# Altium schematic sheet Water_Level_Indicator_PCB.SchDoc
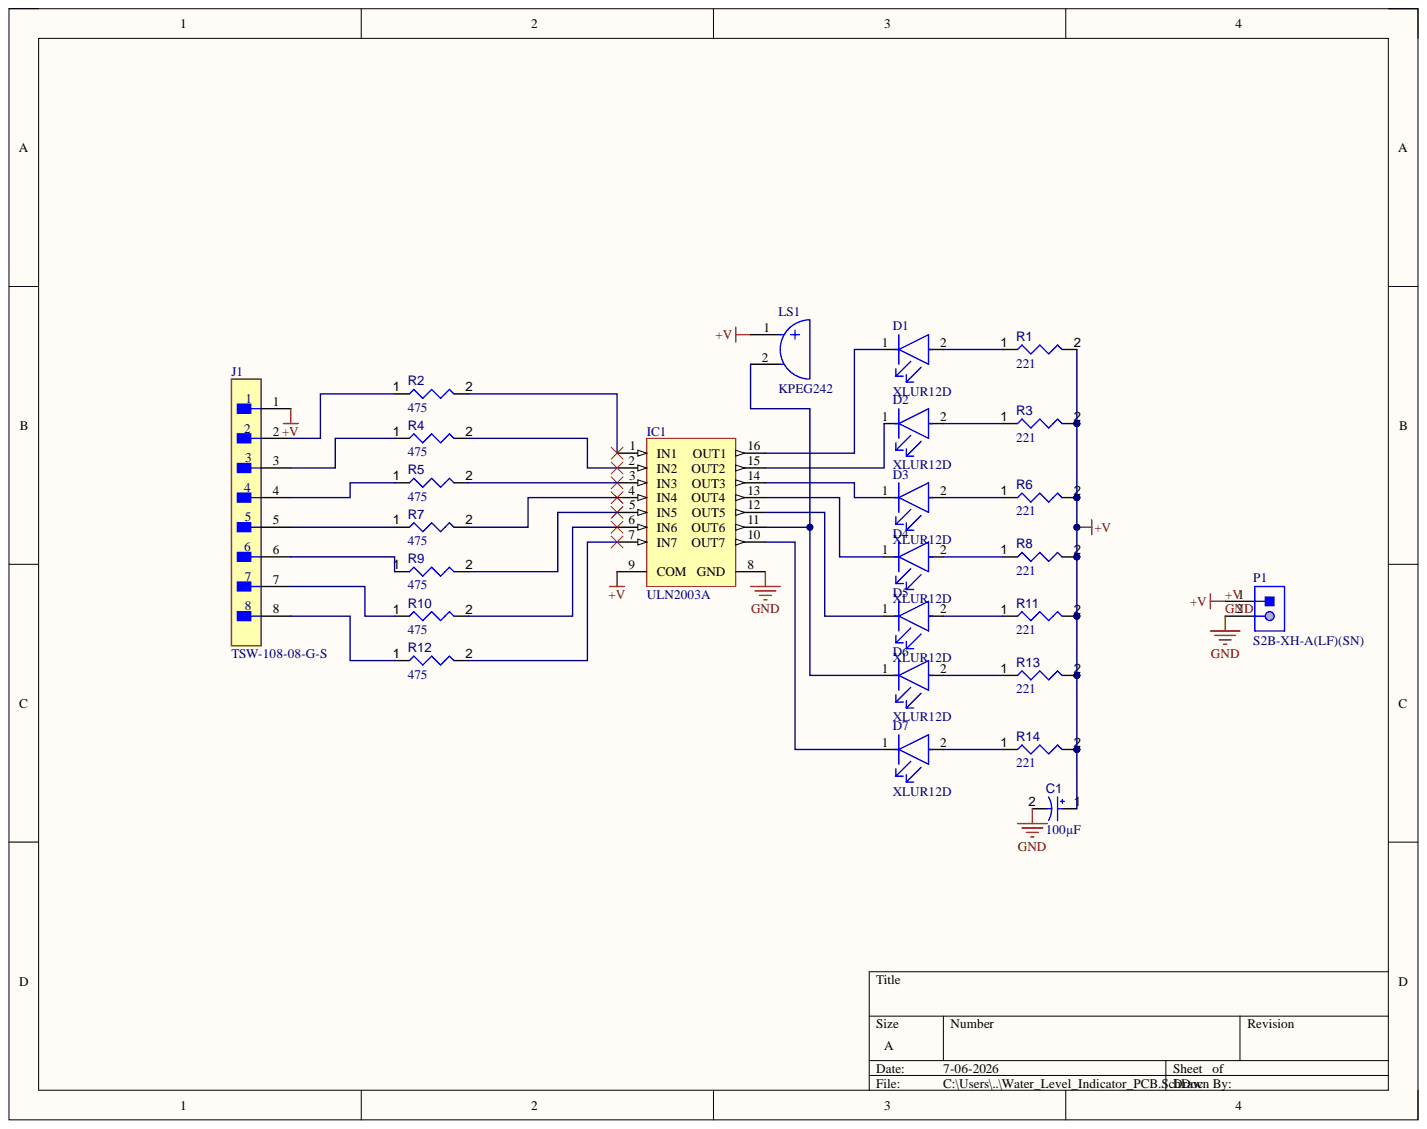
[diagram p0: Water_Level_Indicator_PCB.SchDoc]

## Parts
C1  value 100µF  [CAPPR65-350-1150X800X1250]  (hidden comment: ECA1HM101)
D1  XLUR12D  [FP-XLUR12D-MFG]
D2  XLUR12D  [FP-XLUR12D-MFG]
D3  XLUR12D  [FP-XLUR12D-MFG]
D4  XLUR12D  [FP-XLUR12D-MFG]
D5  XLUR12D  [FP-XLUR12D-MFG]
D6  XLUR12D  [FP-XLUR12D-MFG]
D7  XLUR12D  [FP-XLUR12D-MFG]
IC1  ULN2003A  [STM-DIP-16L]
J1  TSW-108-08-G-S  [FP-TSW-108-08-G-S-MFG]
LS1  KPEG242  [KINGS-KPEG242-2]
P1  S2B-XH-A(LF)(SN)  [JST-S2B-XH-A(LF)(SN)_V]
R1  value 221  [RESA60-630X240]  (hidden comment: MFR-25FBF52-221R)
R2  value 475  [RESA60-630X240]  (hidden comment: MFR-25FBF52-475R)
R3  value 221  [RESA60-630X240]  (hidden comment: MFR-25FBF52-221R)
R4  value 475  [RESA60-630X240]  (hidden comment: MFR-25FBF52-475R)
R5  value 475  [RESA60-630X240]  (hidden comment: MFR-25FBF52-475R)
R6  value 221  [RESA60-630X240]  (hidden comment: MFR-25FBF52-221R)
R7  value 475  [RESA60-630X240]  (hidden comment: MFR-25FBF52-475R)
R8  value 221  [RESA60-630X240]  (hidden comment: MFR-25FBF52-221R)
R9  value 475  [RESA60-630X240]  (hidden comment: MFR-25FBF52-475R)
R10  value 475  [RESA60-630X240]  (hidden comment: MFR-25FBF52-475R)
R11  value 221  [RESA60-630X240]  (hidden comment: MFR-25FBF52-221R)
R12  value 475  [RESA60-630X240]  (hidden comment: MFR-25FBF52-475R)
R13  value 221  [RESA60-630X240]  (hidden comment: MFR-25FBF52-221R)
R14  value 221  [RESA60-630X240]  (hidden comment: MFR-25FBF52-221R)

## Nets
+V: C1.1 IC1.9(COM) J1.1 LS1.1 P1.1 R1.2 R3.2 R6.2 R8.2 R11.2 R13.2 R14.2
GND: C1.2 IC1.8(GND) P1.2
NetD1_1: D1.1 IC1.16(OUT1)
NetD1_2: D1.2 R1.1
NetD2_1: D2.1 IC1.15(OUT2)
NetD2_2: D2.2 R3.1
NetD3_1: D3.1 IC1.14(OUT3)
NetD3_2: D3.2 R6.1
NetD4_1: D4.1 IC1.13(OUT4)
NetD4_2: D4.2 R8.1
NetD5_1: D5.1 IC1.12(OUT5)
NetD5_2: D5.2 R11.1
NetD6_1: D6.1 IC1.11(OUT6) LS1.2
NetD6_2: D6.2 R13.1
NetD7_1: D7.1 IC1.10(OUT7)
NetD7_2: D7.2 R14.1
NetIC1_1: IC1.1(IN1) R2.2
NetIC1_2: IC1.2(IN2) R4.2
NetIC1_3: IC1.3(IN3) R5.2
NetIC1_4: IC1.4(IN4) R7.2
NetIC1_5: IC1.5(IN5) R9.2
NetIC1_6: IC1.6(IN6) R10.2
NetIC1_7: IC1.7(IN7) R12.2
NetJ1_2: J1.2 R2.1
NetJ1_3: J1.3 R4.1
NetJ1_4: J1.4 R5.1
NetJ1_5: J1.5 R7.1
NetJ1_6: J1.6 R9.1
NetJ1_7: J1.7 R10.1
NetJ1_8: J1.8 R12.1

## Library data of the parts
C1 = CMP-001-00026-7: Aluminum Electrolytic Capacitor, 100 uF, +/- 20%, 50 V, -40 to 85 degC, 2-Pin THD, RoHS, Bulk; Manufacturer: Panasonic; Ripple Current: 250mA; Voltage Rating: 50V; Capacitor Type: Electrolytic; Case/Package: Radial; Component Kind: Standard; Datasheet URL: https://industrial.panasonic.com/cdbs/www-data/pdf/RDF0000/ABA0000C1218.pdf; Datasheet Version: Rev. 05, 10/2016; Diameter: 8mm; Lead Pitch: 3.5mm; Manufacturer URL: http://www.panasonic.com/; Max Operating Temperature: 85°C; Min Operating Temperature: -40°C; Mounting Technology: TH; Package Description: 2-Pin Radial Lead, Dia 8 mm, Height 12.5 mm; Package Quantity: 200; Package Reference: CAPPR65-350-1150X800X1250; Packaging: Bulk; Pins: 2; Ripple Current (AC): 250mA; RoHS Compliant: True; Tolerance: 20%; Library Name: Yuvan Bala
D1-D7 = CMP-02085-08376351-1: 627nm Red LED; 5x8.6mm, Through-Hole Mount with Red Diffused Round Lamp; Dominant Wavelength: 617nm; Forward Voltage: 1.9V; Luminous Intensity: 39mcd; Test Current: 10mA; Viewing Angle: 30°; Case/Package: Radial; Color: Red; Component Kind: Standard; Height: 8.6mm; Lens Color: Red; Lens Transparency: Diffused; Library Name: Altium Content Vault; Lifecycle Status: Production; Mount: Through Hole; RoHS: Compliant; Wavelength: 627nm
IC1 = CMP-2000-07351-1: Seven Darlington Array, 50 V, 0.5 A, -40 to 85 degC, 16-Pin DIP, RoHS, Tube; Manufacturer: STMicroelectronics; Collector Emitter Voltage V(br)ceo: 50V; DC Collector Current: 500mA; DC Current Gain hFE: 1000hFE; No. of Pins: 16Pins; Output Current: 0.5A; SVHC: NoSVHC(17-Dec-2015); Transistor Polarity: NPN; Automotive Qualification Standard: -; Component Kind: Standard; Datasheet URL: http://www2.st.com/content/ccc/resource/technical/document/datasheet/f3/6e/c8/64/4d/b3/4e/38/CD00001244.pdf/files/CD00001244.pdf/jcr:content/translations/en.CD00001244.pdf; Datasheet Version: Rev. 8, 06/2012; Library Name: Altium Content Vault; Manufacturer URL: http://www.st.com/; Mounting Technology: ThroughHole; MSL: MSL2-1year; Operating Temperature Max: 85°C; Package Description: 16-Pin DIP, Body 19.7 x 7.1 mm, Pitch 2.54 mm; Package Reference: DIP-16L; PartNumber: ULN2003A; Power Dissipation Pd: -; Product Range: -; Transistor Case Style: DIP; VOUT: 50V
J1 = CMP-02766-000001-1: CONN HEADER VERT 8POS 2.54MM; Manufacturer: Samtec; Conn Gender: Male; Conn Orientation: Straight; Number Of Contacts: 8; Automotive: No; Category: Conn; Component Kind: Standard; ComponentLink2Description: Datasheet; ComponentLink2URL: http://suddendocs.samtec.com/catalog_english/tsw_th.pdf; Contact Material: Gold,Nickel; Device Class L1: Connectors; Device Class L2: Headers and Wire Housings; Device Class L3: unset; Digikey Description: CONN HEADER VERT 8POS 2.54MM; Footprint Url: http://suddendocs.samtec.com/prints/tsw-xxx-xx-x-x-xx-xxx-footprint.pdf; Height: 8.71mm; Lead Free: Yes; Library Name: Altium Content Vault; Manufacturer Part Number: TSW-108-08-G-S; Mouser Description: TSW - Samtec 2.54mm Pin Header 13.46mm Overall Pin Length 5.08mm Tail; Number Of Rows: 1; Octopart: https://octopart.com/tsw-108-08-g-s-samtec-272653; Package: TSW-108-08-G-S; Pitch: 2.54mm; RoHS: TRUE; Temperature: -55°C to +125°C; Temperature Range High: +125°C; Temperature Range Low: -55°C
LS1 = CMP-2000-06518-1: Piezo Indicator, 4100 Hz, 3 to 16 V, -20 to 70 degC, 2-Pin THD, RoHS; Manufacturer: KingstateElectronicsCorp.; PartNumber: KPE-242; Current - Max: 7A; Frequency Range: 3600to4600Hz; Maximum Resonant Frequency: 4600Hz; Maximum Supply Current: 7mA; Minimum Sound Pressure: 70dBA; Product Diameter: 13.7mm; Product Height: 7.6mm; Tone Mode: Continuous; Typical Operating Supply Voltage: 12Vdc; Category: AudioIndicatorsandAlerts; Component Kind: Standard; Datasheet URL: https://datasheet.ciiva.com/11776/0900766b8068f3a2-11776713.pdf; Datasheet Version: 01/2006; Library Name: Altium Content Vault; Manufacturer URL: http://www.kingstate.com.tw/index.php/en/; Mounting: ThroughHole; Mounting Technology: ThroughHole; Operating Temperature: -20to70°C; Package Description: 2-Pin Through Hole Device, Body Dia 13.7 mm, Pitch 7.62 mm; Package Reference: KPEG242; Technology: Piezo; Termination Method: Pin; Type: Indicator
P1 = CMP-002-00032-2: Male Header, Pitch 2.5 mm, 1 x 2 Position, Height 6.1 mm, Tail Length 3.4 mm, -25 to 85 degC, RoHS, Bulk; Manufacturer: JST; Case/Package: S2B-XH-A(LF)(SN); Component Kind: Standard; Connector Type: Header; Datasheet URL: http://www.jst-mfg.com/product/pdf/eng/eXH.pdf; Datasheet Version: May-16; Gender: Male; Manufacturer URL: http://www.jst.com/home2.html; Max Operating Temperature: 85°C; Min Operating Temperature: -25°C; Mounting Technology: TH; Package Description: XH Series Disconnectable Crimp Style Connector, Pitch 2.5 mm, 1 x 2 Position, Height 6.1 mm, Tail Length 3.4 mm; Package Reference: S2B-XH-A(LF)(SN); RoHS Compliant: Unknown; Library Name: Yuvan Bala
R1 R3 R6 R8 R11 R13 R14 = CMP-009-00051-4: Axial Resistor, 221 Ohm, +/- 1%, 250 mW, -55 to 155 degC, 2-Pin THD, RoHS, Bulk; Manufacturer: Yageo; Power: 250mW; Voltage Rating: 250V; Case/Package: Axial; Component Kind: Standard; Datasheet URL: http://www.yageo.com/documents/recent/Yageo%20LR_MFR_2013.pdf; Datasheet Version: Apr-13; Manufacturer URL: http://www.yageo.com/; Max Operating Temperature: 155°C; Min Operating Temperature: -55°C; Mounting Technology: TH; Package Description: 2-Pin Axial Lead, Dia 2.4 mm, Body Length 6.3 mm; Package Quantity: 200; Package Reference: MFR-25; Packaging: Bulk; RoHS Compliant: True; Tolerance: 1%; Library Name: Yuvan Bala
R2 R4 R5 R7 R9 R10 R12 = CMP-009-00056-4: Axial Resistor, 475 Ohm, +/- 1%, 0.25 W, -55 to 155 degC, 2-Pin THD, RoHS, Bulk; Manufacturer: Yageo; Power: 250mW; Voltage Rating: 250V; Case/Package: Axial; Component Kind: Standard; Datasheet URL: http://www.yageo.com/documents/recent/Yageo%20LR_MFR_2013.pdf; Datasheet Version: Apr-13; Manufacturer URL: http://www.yageo.com/; Max Operating Temperature: 155°C; Min Operating Temperature: -55°C; Mounting Technology: TH; Package Description: 2-Pin Axial Lead, Dia 2.4 mm, Body Length 6.3 mm; Package Quantity: 200; Package Reference: MFR-25; Packaging: Bulk; RoHS Compliant: True; Tolerance: 1%; Library Name: Yuvan Bala
